# Altium schematic sheet PP_0_3.SchDoc
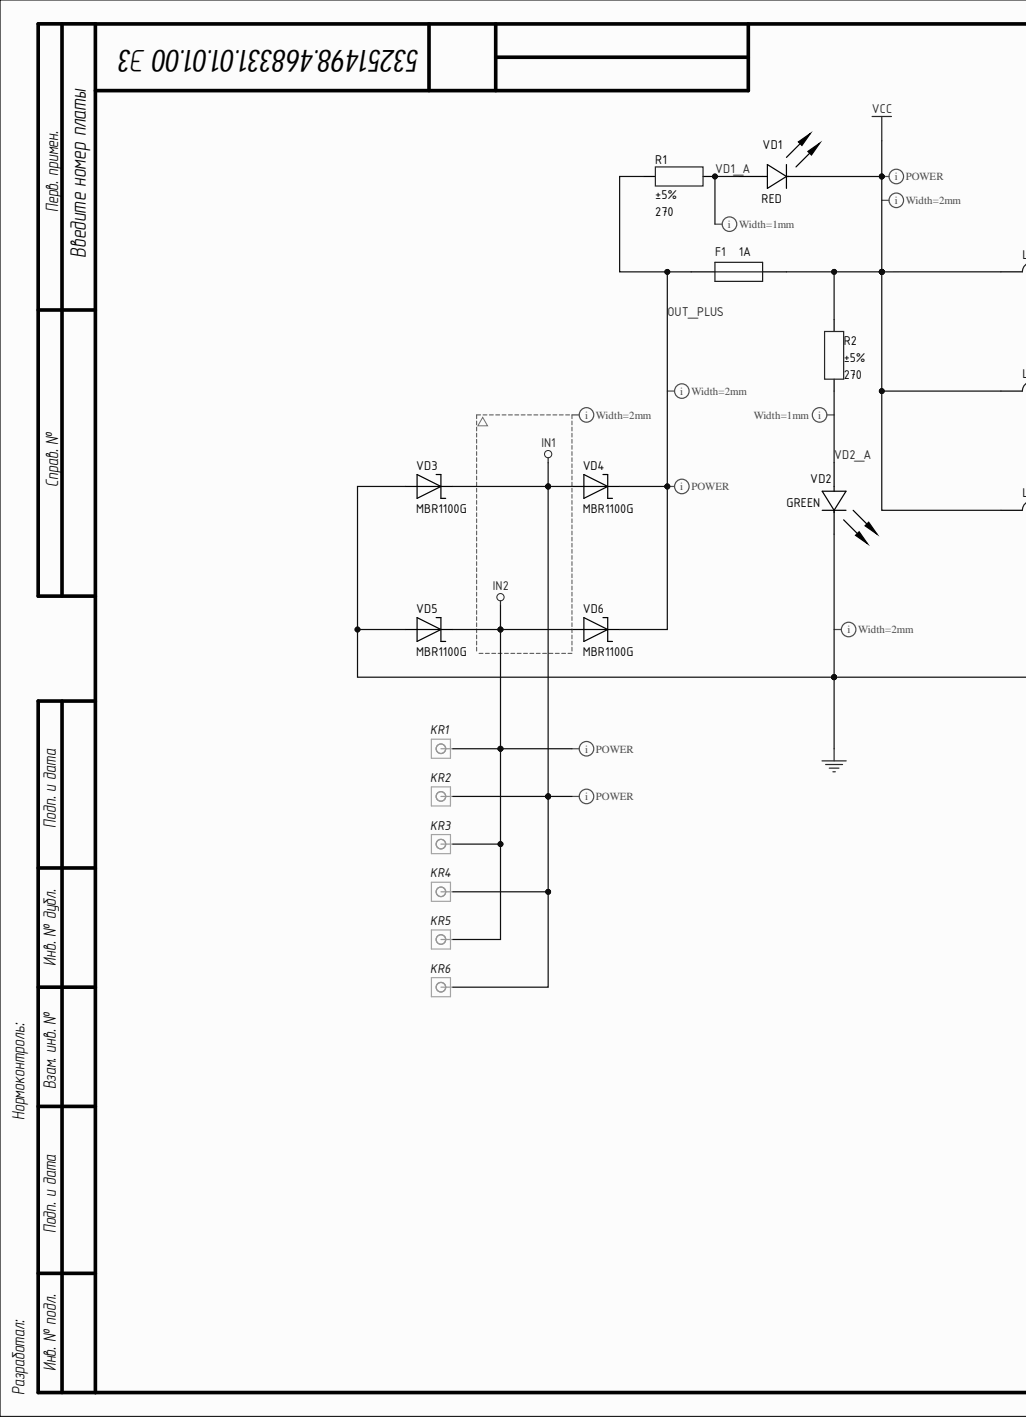
[diagram p0: PP_0_3.SchDoc, left]
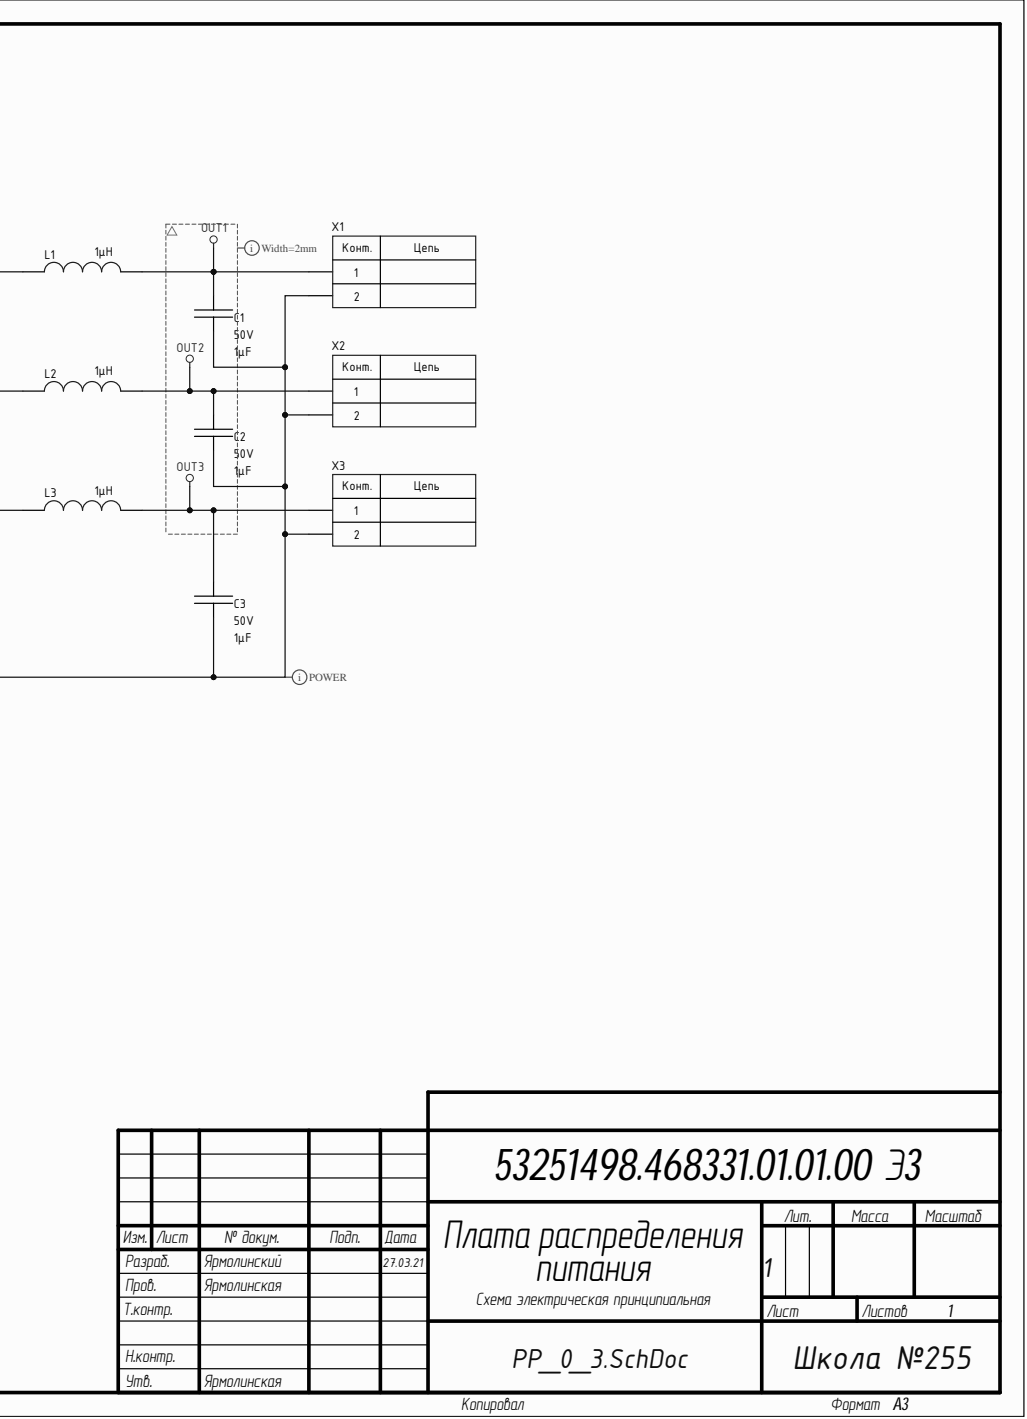
[diagram p1: PP_0_3.SchDoc, right]

## Parts
C1  value 1μF  Voltage: 50V  [CAP: 0805, Thru-Hole]  (hidden comment: Ceramic capacitor, 1μF, X7R, ±10%, 50V, 0805, -55...+125°C)
C2  value 1μF  Voltage: 50V  [CAP: 0805, Thru-Hole]  (hidden comment: Ceramic capacitor, 1μF, X7R, ±10%, 50V, 0805, -55...+125°C)
C3  value 1μF  Voltage: 50V  [CAP: 0805, Thru-Hole]  (hidden comment: Ceramic capacitor, 1μF, X7R, ±10%, 50V, 0805, -55...+125°C)
F1  value 1A  [MF-R_Style_1]  (hidden comment: Fuse 1A)
KR1  KR_3  [KR_3_0]  (value not drawn)
KR2  KR_3  [KR_3_0]  (value not drawn)
KR3  KR_3  [KR_3_0]
KR4  KR_3  [KR_3_0]  (value not drawn)
KR5  KR_3  [KR_3_0]  (value not drawn)
KR6  KR_3  [KR_3_0]  (value not drawn)
L1  value 1μH  [IND: BL-01]  (hidden comment: Fixed inductor, 1μH, ±20%, BL-01, Kool Mu, µ=125, 90 нГн/витков2)
L2  value 1μH  [IND: BL-01]  (hidden comment: Fixed inductor, 1μH, ±20%, BL-01, Kool Mu, µ=125, 90 нГн/витков2)
L3  value 1μH  [IND: BL-01]  (hidden comment: Fixed inductor, 1μH, ±20%, BL-01, Kool Mu, µ=125, 90 нГн/витков2)
R1  value 270  Tolerance: ±5%  [AXIAL-0.3]  (hidden comment: Resistor film, 270, ±5%, CF-25, 0.25W, -55...+125°C)
R2  value 270  Tolerance: ±5%  [AXIAL-0.3]  (hidden comment: Resistor film, 270, ±5%, CF-25, 0.25W, -55...+125°C)
VD1  Color: RED  [LED: 3MM_R]  (hidden comment: LED, Red, *, *, 5mm, -55...+150°C)
VD2  Color: GREEN  [LED: 3MM_G]  (hidden comment: LED, Green, *, *, 5mm, -55...+150°C)
VD3  PartNumber: MBR1100G  [DIODE: DO-41]  (hidden comment: Schottky diode, 100V, 1A, 1V, 15pF, DO-41, -55...+150°C)
VD4  PartNumber: MBR1100G  [DIODE: DO-41]  (hidden comment: Schottky diode, 100V, 1A, 1V, 15pF, DO-41, -55...+150°C)
VD5  PartNumber: MBR1100G  [DIODE: DO-41]  (hidden comment: Schottky diode, 100V, 1A, 1V, 15pF, DO-41, -55...+150°C)
VD6  PartNumber: MBR1100G  [DIODE: DO-41]  (hidden comment: Schottky diode, 100V, 1A, 1V, 15pF, DO-41, -55...+150°C)
X1  Terminal connector, 2pin, 3.5mm, 300VAC, 10A  [CON: DG350-3.5-02P]  (value not drawn)
X2  Terminal connector, 2pin, 3.5mm, 300VAC, 10A  [CON: DG350-3.5-02P]  (value not drawn)
X3  Terminal connector, 2pin, 3.5mm, 300VAC, 10A  [CON: DG350-3.5-02P]  (value not drawn)

## Nets
GND: C1.2 C2.1 C3.2 VD2.2 VD3.1 VD5.1 X1.2 X2.2 X3.2
IN1: KR2.0 KR4.0 KR6.0 VD3.2 VD4.1
IN2: KR1.0 KR3.0 KR5.0 VD5.2 VD6.1
OUT1: C1.1 L1.1 X1.1
OUT2: C2.2 L2.1 X2.1
OUT3: C3.1 L3.1 X3.1
VCC: F1.2 L1.2 L2.2 L3.2 R2.2 VD1.2
OUT_PLUS: F1.1 R1.1 VD4.2 VD6.2
VD1_A: R1.2 VD1.1
VD2_A: R2.1 VD2.1

## Library data of the parts
C1-C3 = Ceramic_0805: К10-17 0805; Manufacturer: Murata; PartNumber: RDER71H105K2K1C03B; Component Kind: Standard; HelpURL: https://www.chipdip.ru/product0/9000238474; Package: 0805; Temperature: -55...+125°C; Tolerance: ±10%; TypeCapacitor: Ceramic capacitor; TypeDielectric: X7R; ValueManufacturerPartNumber: Ceramic capacitor, 1μF, X7R, ±10%, 50V, 0805, -55...+125°C; Library Name: RAZNOE.IntLib
  C1: ClassName: Groupe1
  C2: ClassName: Groupe2
  C3: ClassName: Groupe3
F1 = Fuse 1A: PartNumber: MF-R300; Component Kind: Standard; Description: Fuse 1A; LatestRevisionDate: 17-Jul-2002; LatestRevisionNote: Re-released for DXP Platform.; PackageDescription: Thru-Hole; 2 Leads; PackageReference: MF-R_Style_2; Published: 8-Jun-2000; Simulation: FUSE; Library Name: RAZNOE.IntLib; Publisher: Altium Limited
KR1-KR6 = KR_3: Mounting point; Component Kind: Standard; Library Name: Check_Points.IntLib
L1 = IND: BL-01: Индуктивность  подавления ЭМП; Manufacturer: Murata; PartNumber: BL01RN1A2A2B; TypeMatiriel: Kool Mu; AL: 90 нГн/витков2; ClassName: Groupe1; Component Kind: Standard; HelpURL: https://www.chipdip.ru/product/bl01rn1a2a2b; MaterialPermeability: µ=125; Package: BL-01; Tolerance: ±20%; TypeInductor: Fixed inductor; ValueManufacturerPartNumber: Fixed inductor, 1μH, ±20%, BL-01, Kool Mu, µ=125, 90 нГн/витков2; Library Name: RAZNOE.IntLib
L2 L3 = IND: BL-01: Индуктивность  подавления ЭМП; Manufacturer: Murata; PartNumber: 30201567; TypeMatiriel: Kool Mu; AL: 90 нГн/витков2; Component Kind: Standard; HelpURL: https://www.chipdip.ru/product/bl01rn1a2a2b; MaterialPermeability: µ=125; Package: BL-01; Tolerance: ±20%; TypeInductor: Fixed inductor; ValueManufacturerPartNumber: Fixed inductor, 1μH, ±20%, BL-01, Kool Mu, µ=125, 90 нГн/витков2; Library Name: RAZNOE.IntLib
  L2: ClassName: Groupe2
  L3: ClassName: Groupe3
R1 R2 = ResistorCF-25: Manufacturer: Yageo; PartNumber: СF-1/4W-100RF; Component Kind: Standard; HelpURL: https://www.digikey.com/product-detail/en/yageo/RC0201JR-07100RL/311-100NCT-ND/1949006; Package: CF-25; Power: 0.25W; Temperature: -55...+125°C; TypeResistor: Resistor film; ValueManufacturerPartNumber: Resistor film, 270, ±5%, CF-25, 0.25W, -55...+125°C; Library Name: REZISTOR_THRU.IntLib
VD1 = LED_single: PartNumber: GNL-5013HT; ColorParameter: Red; Component Kind: Standard; Library Name: diode.IntLib; Package: 5mm; Temperature: -55...+150°C; TypeDiode: LED; ValueManufacturerPartNumber: LED, Red, *, *, 5mm, -55...+150°C
VD2 = LED_single: PartNumber: GNL-5013GT; ColorParameter: Green; Component Kind: Standard; Library Name: diode.IntLib; Package: 5mm; Temperature: -55...+150°C; TypeDiode: LED; ValueManufacturerPartNumber: LED, Green, *, *, 5mm, -55...+150°C
VD3-VD6 = Schottky_1A: Manufacturer: Diodes Incorporated; ClassName: Rectifier; Component Kind: Standard; Current: 1A; HelpURL: https://www.digikey.com/product-detail/en/diodes-incorporated/MBR0580S1-7/MBR0580S1-7DICT-ND/5080371; Package: DO-41; RecoveryTime: 15pF; Temperature: -55...+150°C; TypeDiode: Schottky diode; ValueManufacturerPartNumber: Schottky diode, 100V, 1A, 1V, 15pF, DO-41, -55...+150°C; Voltage: 100V; VoltageDrop: 1V; Library Name: DIODE_SHOTTKI.IntLib
X1-X3 = Connector_terminal_2pin: Manufacturer: Degson; PartNumber: DG350-3.5-02P-14; CommentText: "Разъем"; Component Kind: Standard; CurrentMax: 10A; HelpURL: https://www.chipdip.ru/product/dg350-3.5-02p-12; Library Name: connector.IntLib; Multichannel: 2pin; Pitch: 3.5mm; TypeConnector: Terminal connector; ValueManufacturerPartNumber: Terminal connector, 2pin, 3.5mm, 300VAC, 10A; VoltageMax: 300VAC
  X1: ClassName: Groupe1
  X2: ClassName: Groupe2
  X3: ClassName: Groupe3
